# Altium schematic sheet PAGE2-MCU,LORA.SchDoc (continued)

## Library data of the parts
A1 = SR42I010-R: Antenna SMD -4dBi Gain 870MHz/928MHz Automotive 7-Pin SMD T/R; Manufacturer: Antenova; Component Kind: Standard; Manufacturer Part Number: SR42I010-R; Pricing 1: 1 = 2.36000, 10 = 1.90000, 50 = 1.90000, 100 = 1.52000, 1000 = 1.07000, 10000 = 0.95900 (USD); Stock 1: 3154; Supplier 1: Mouser; Supplier Part Number 1: 237-SR42I010-R; Library Name: Arribada-turtle-gps-tag-lora-addon.SchLib
C1 = 2.2iF/0402: Multilayer Ceramic Capacitors MLCC - SMD/SMT 0402 2.2pF 50Volts C0G +/-0.05pF; Manufacturer: Murata; Manufacturer Part Number: GJM1555C1H2R2WB01D; Voltage Rating: 50V; Capacitance: 2.2pF; Case/Package: 0402; Case Code (Imperial): 0402; Case Code (Metric): 1005; Component Kind: Standard; Depth: 0.5mm; Dielectric: C0G; Height: 0.5mm; Lead Free: Lead Free; Length: 0.039inch; Library Name: Capacitors_Schlib.SchLib; Max Operating Temperature: 125°C; Min Operating Temperature: -55°C; Mount: Surface Mount; Package Quantity: 10000; Packaging: Tape and Reel; Pricing 1: 10 = 0.19700, 100 = 0.09710, 500 = 0.05500, 2500 = 0.04500 (GBP); Radiation Hardening: No; RoHS: Yes; Stock 1: 14764; Supplier 1: Farnell; Supplier Part Number 1: 2781431; Termination: SMD/SMT; Thickness: 0.022inch; Voltage Rating (DC): 50V; Width: 0.02inch
C2 C6-C10 C13 C17 C18 C21 C23 = 100nF: MURATA - GRM188R71C104KA01J - CAP, MLCC, X7R, 0.1UF, 16V, 0603; Capacitance: 0.1; Capacitance Tolerance: ± 10%; Ceramic Capacitor Case: 0603 [1608 Metric]; Dielectric Characteristic: X7; Product Range: GRM Series; SVHC: No SVHC (20-Jun-2016; Voltage Rating: 16; Automotive Qualification Standard: -; Component Kind: Standard; ComponentLink1Description: http://www.farnell.com/datasheets/2189124.pdf; ComponentLink1URL: http://www.farnell.com/datasheets/2189124.pdf; Library Name: Capacitors.IntLib; Manufacturer: MURATA; Manufacturer Part Number: GRM188R71C104KA01J; MSL: MSL 1 - Unlimited; Operating Temperature Max: 125; Operating Temperature Min: -55; Packaging: Cut Tape; Pricing 1: 10=0.0145, 100=0.0126, 500=0.0081, 2500=0.0059, 5000=0.0052, 10000=0.0046, 50000=0.0036, 100000=0.0035 (GBP); RoHS: YES; Stock 1: 18297; Supplier 1: Farnell; Supplier Part Number 1: 2688519
C3-C5 C20 = 1uF: MURATA - GRM188R61H105KAALD - CAP, MLCC, X5R, 1UF, 50V, 0603; Capacitance: 1; Capacitance Tolerance: ± 10%; Capacitor Terminals: SMD<!--FANDB--><div class="features-and-benefits-container"><div class="features-and-benefits-description"><span class="features-benefits-value">The Murata GRM series capacitors are Multilayer Ceramic Chip Capacitors (MLCC) used for general purpose applications. These MLCC capacitors are classified into temperature compensating and high dielectric constant types depending on the change in electrostatic capacitance due to change in temperature. The temperature compensating capacitors feature a small rate of change in electrostatic capacitance as the temperature changes and are used for applications such as filters and high frequency circuit matching. The high dielectric constant capacitors use materials with a high dielectric constant and feature a large electrostatic capacitance. Typical applications are power supply decoupling and smoothing circuits.</span></div><div class="features-and-benefits-list"><ul class="features-and-benefits-list-list"><li class="features-and-benefits-list-item">Large capacity and small size in a multilayer structure</li><li class="features-and-benefits-list-item">Capacitance value ranging from 0.1pF to 220?F</li><li class="features-and-benefits-list-item">Tin plated external electrodes, excellent solderability</li><li class="features-and-benefits-list-item">Provides good high frequency characteristics</li><li class="features-and-benefits-list-item">Excellent pulse response</li><li class="features-and-benefits-list-item">High reliability</li><li class="features-and-benefits-list-item">Capacitor size varies from 0.4×0.2mm to 5.7×5.0mm</li><li class="features-and-benefits-list-item">Rated voltage of 2.5VDC to 3.15; Ceramic Capacitor Case: 0603 [1608 Metric]; Dielectric Characteristic: X5; Product Range: GRM Series; SVHC: No SVHC (17-Dec-2015); Voltage Rating: 50; Automotive Qualification Standard: -; Capacitance Tolerance ±: ± 10%; Component Kind: Standard; ComponentLink1Description: http://www.farnell.com/datasheets/2048611.pdf; ComponentLink1URL: http://www.farnell.com/datasheets/2048611.pdf; Library Name: Capacitors.IntLib; Manufacturer: MURATA; Manufacturer Part Number: GRM188R61H105KAALD; MSL: -; Operating Temperature Max: 85; Operating Temperature Min: -55; Packaging: Cut Tape; Pricing 1: 10=0.093, 100=0.062, 500=0.0507, 1000=0.0465, 2000=0.0429, 4000=0.0399, 20000=0.0372, 40000=0.0365 (GBP); RoHS: YES; Stock 1: 62682; Supplier 1: Farnell; Supplier Part Number 1: 1845736
C11 C12 C14 C15 = 20pF: MURATA - GRM1885C1H200JA01D - CAPACITOR, MLCC, C0G, 20PF, 50V, 0603; Capacitance: 20; Capacitance Tolerance: ± 5%; Ceramic Capacitor Case: 0603 [1608 Metric]; Dielectric Characteristic: C0G / NP0; Product Range: GRM Series; SVHC: No SVHC (17-Dec-2015; Voltage Rating: 50; Automotive Qualification Standard: -; Component Kind: Standard; ComponentLink1Description: http://www.farnell.com/datasheets/2048077.pdf; ComponentLink1URL: http://www.farnell.com/datasheets/2048077.pdf; Library Name: Capacitors.IntLib; Manufacturer: MURATA; Manufacturer Part Number: GRM1885C1H200JA01D; MSL: MSL 1 - Unlimited; Operating Temperature Max: 125; Operating Temperature Min: -55; Packaging: Cut Tape; Pricing 1: 10=0.0245, 100=0.0159, 500=0.0123, 1000=0.0095, 2000=0.0093, 4000=0.0073, 20000=0.0062, 40000=0.0057 (GBP); RoHS: YES; Stock 1: 5812; Supplier 1: Farnell; Supplier Part Number 1: 2456108
C16 = 330pF: Cap Ceramic 330pF 50V C0G 5% SMD 0603 125C Paper T/R; Manufacturer: Walsin Technologies; Manufacturer Part Number: 0603N331J500CT; Capacitance: 330pF; Case/Package: 0603; Case Code (Imperial): 0603; Case Code (Metric): 1608; Component Kind: Standard; Depth: 0.8mm; Dielectric: C0G; Length: 1.6mm; Library Name: Capacitors.IntLib; Max Operating Temperature: 125°C; Min Operating Temperature: -55°C; Packaging: Tape and Reel; Pricing 1: 10=0.066, 100=0.0446, 500=0.0195, 1000=0.0138 (GBP); Radiation Hardening: No; REACH SVHC: Unknown; Stock 1: 9688; Supplier 1: Farnell; Supplier Part Number 1: 2496902; Tolerance: 5%; Voltage Rating: 50V; Voltage Rating (DC): 50V
C19 = 2.2uF/0805: Multilayer Ceramic Capacitors MLCC - SMD/SMT 2.2uF 25V X7R 10%; Manufacturer: Yageo; Manufacturer Part Number: CC0805KKX7R8BB225; Capacitance: 2.2uF; Case/Package: 0805; Case Code (Imperial): 0805; Case Code (Metric): 2012; Component Kind: Standard; ComponentLink1Description: https://datasheet.ciiva.com/7009/775840-7009706.pdf; ComponentLink1URL: https://datasheet.ciiva.com/7009/775840-7009706.pdf; Composition: Ceramic; Depth: 1.25mm; Dielectric: X7R; Halogen Free: Halogen Free; Height: 0.3mm; Lead Free: Lead Free; Length: 2mm; Library Name: Capacitors.IntLib; Max Operating Temperature: 125°C; Min Operating Temperature: -55°C; Mount: Surface Mount; Number of Pins: 2; Packaging: Tape and Reel; Pricing 1: 5=0.269, 50=0.163, 250=0.109, 500=0.0929, 1500=0.0722, 3000=0.0594, 15000=0.05 (GBP); Radiation Hardening: No; REACH SVHC: Unknown; Stock 1: 0; Supplier 1: Farnell; Supplier Part Number 1: 1458904; Termination: SMD/SMT; Thickness: 0.057inch; Tolerance: 10%; Voltage Rating: 25V; Voltage Rating (DC): 25V; Width: 1.25mm
C22 = 10nF: KEMET - C0603X103K5RECAUTO - CAP, MLCC, AEC-Q200, X7R, 0.01UF, 50V; Manufacturer: TDK EPCOS; Component Kind: Standard; ComponentLink1Description: http://www.farnell.com/datasheets/2290180.pdf; ComponentLink1URL: http://www.farnell.com/datasheets/2290180.pdf; Library Name: Capacitors.IntLib; Manufacturer Part Number: C0603X103K5RECAUTO; Pricing 1: 10=0.133, 100=0.0731, 500=0.055, 1000=0.0465, 2000=0.0408, 4000=0.0336, 20000=0.0295 (GBP); Stock 1: 307350; Supplier 1: Farnell; Supplier Part Number 1: 2760492
CON1 = 53261-1471: 1.25mm (.049") Pitch PicoBlade Header, Surface Mount, Right Angle, Lead-free, 14 Circuits; Manufacturer: Molex; Body Plating: Tin; Contact Resistance: 20mR; Current Rating: 1A; Gender: Male; Glow Wire Compliant: Non-compliant; Insulation Resistance: 100MR; Number of Circuits: 14; Voltage Rating: 125V; Color: Beige; Component Kind: Standard; ComponentLink1Description: http://www.onlinecomponents.com/productFiles/mf-MOL/318250532611471_pcb_headers.pdf?src-supplier=Onlinecomponents.com; ComponentLink1URL: http://www.onlinecomponents.com/productFiles/mf-MOL/318250532611471_pcb_headers.pdf?src-supplier=Onlinecomponents.com; ComponentLink2Description: http://uk.rs-online.com/webdocs/13c4/0900766b813c44d0.pdf?src-supplier=RSComponents; ComponentLink2URL: http://uk.rs-online.com/webdocs/13c4/0900766b813c44d0.pdf?src-supplier=RSComponents; ComponentLink3Description: https://www.distrelec.biz/Web/Downloads/74/_e/gh116861-116874_e.pdf?mime=application%2Fpdf&src-supplier=Distrelec; ComponentLink3URL: https://www.distrelec.biz/Web/Downloads/74/_e/gh116861-116874_e.pdf?mime=application%2Fpdf&src-supplier=Distrelec; ComponentLink4Description: http://www.farnell.com/datasheets/1767586.pdf?src-supplier=Element14; ComponentLink4URL: http://www.farnell.com/datasheets/1767586.pdf?src-supplier=Element14; ComponentLink5Description: http://www.mouser.com/ds/2/276/0532611471_PCB_HEADERS-256969.pdf?src-supplier=Mouser; ComponentLink5URL: http://www.mouser.com/ds/2/276/0532611471_PCB_HEADERS-256969.pdf?src-supplier=Mouser; ComponentLink6Description: http://datasheet.octopart.com/532611471-Molex-datasheet-8343080.pdf?src-supplier=TME; ComponentLink6URL: http://datasheet.octopart.com/532611471-Molex-datasheet-8343080.pdf?src-supplier=TME; ComponentLink7Description: http://datasheet.octopart.com/53261-1471-Molex-datasheet-13786036.pdf?src-supplier=Molex; ComponentLink7URL: http://datasheet.octopart.com/53261-1471-Molex-datasheet-13786036.pdf?src-supplier=Molex; ComponentLink8Description: http://sigma.octopart.com/16413766/technical_drawing/Molex-53261-1471.pdf?src-supplier=Molex; ComponentLink8URL: http://sigma.octopart.com/16413766/technical_drawing/Molex-53261-1471.pdf?src-supplier=Molex; ComponentLink9Description: http://datasheet.octopart.com/53261-1471-Molex-datasheet-120153911.pdf?src-supplier=Allied+Electronics; ComponentLink9URL: http://datasheet.octopart.com/53261-1471-Molex-datasheet-120153911.pdf?src-supplier=Allied+Electronics; ComponentLink10Description: http://datasheet.octopart.com/0532611471-Molex-datasheet-11835648.pdf?src-supplier=Newark; ComponentLink10URL: http://datasheet.octopart.com/0532611471-Molex-datasheet-11835648.pdf?src-supplier=Newark; ComponentLink11Description: http://datasheet.octopart.com/53261-1471-Molex-datasheet-82508140.pdf?src-supplier=Farnell; ComponentLink11URL: http://datasheet.octopart.com/53261-1471-Molex-datasheet-82508140.pdf?src-supplier=Farnell; ComponentLink12Description: http://datasheet.octopart.com/53261-1471-Molex-datasheet-130544.pdf?src-supplier=Digi-Key; ComponentLink12URL: http://datasheet.octopart.com/53261-1471-Molex-datasheet-130544.pdf?src-supplier=Digi-Key; ComponentLink13Description: http://datasheet.octopart.com/532611471-Molex-datasheet-509611.pdf?src-supplier=Farnell; ComponentLink13URL: http://datasheet.octopart.com/532611471-Molex-datasheet-509611.pdf?src-supplier=Farnell; ComponentLink14Description: http://datasheet.octopart.com/53261-1471-Molex-datasheet-11023919.pdf?src-supplier=Farnell; ComponentLink14URL: http://datasheet.octopart.com/53261-1471-Molex-datasheet-11023919.pdf?src-supplier=Farnell; ComponentLink15Description: http://datasheet.octopart.com/53261-1471-Molex-datasheet-100429.pdf?src-supplier=Element14; ComponentLink15URL: http://datasheet.octopart.com/53261-1471-Molex-datasheet-100429.pdf?src-supplier=Element14; ComponentLink16Description: http://datasheet.octopart.com/53261-1471-Molex-datasheet-8522546.pdf?src-supplier=Element14; ComponentLink16URL: http://datasheet.octopart.com/53261-1471-Molex-datasheet-8522546.pdf?src-supplier=Element14; ComponentLink17Description: http://datasheet.octopart.com/53261-1471-Molex-datasheet-23710581.pdf?src-supplier=Electro+Sonic; ComponentLink17URL: http://datasheet.octopart.com/53261-1471-Molex-datasheet-23710581.pdf?src-supplier=Electro+Sonic; ComponentLink18Description: http://datasheet.octopart.com/53261-1471-Molex-datasheet-34442330.pdf?src-supplier=Allied+Electronics; ComponentLink18URL: http://datasheet.octopart.com/53261-1471-Molex-datasheet-34442330.pdf?src-supplier=Allied+Electronics; ComponentLink19Description: http://datasheet.octopart.com/53261-1471-Molex-datasheet-48284398.pdf?src-supplier=Newark; ComponentLink19URL: http://datasheet.octopart.com/53261-1471-Molex-datasheet-48284398.pdf?src-supplier=Newark; Contact Material: Brass,Bronze,Tin; Contact Plating: Tin; Height: 3.4mm; Housing Material: Thermoplastic; Lead Free: Lead Free; Lead Pitch: 1.25mm; Manufacturer Part Number: 53261-1471; Max Operating Temperature: 85°C; Min Operating Temperature: -40°C; Mount: Surface Mount; Number of Contacts: 14; Number of Positions: 14; Number of Rows: 1; Orientation: Right Angle; Package Quantity: 1000; Packaging: Tape and Reel; Pitch: 1.25mm; Pricing 1: 10 = 1.74000, 50 = 1.63000, 100 = 1.51000, 250 = 1.37000, 500 = 1.09000, 1500 = 0.96100 (GBP); Radiation Hardening: No; REACH SVHC: Unknown; Stock 1: 2619; Supplier 1: Farnell; Supplier Part Number 1: 1125364; Termination: SMD/SMT; Voltage Rating (AC): 125V; Voltage Rating (DC): 125V; Working Voltage: 125V; Library Name: Arribada-turtle-gps-tag-lora-addon.SchLib
CON2 = Micro USB CHINA 1: Component Kind: Standard; Library Name: USB_Connector_Lib_20150530.IntLib
CON3 = tag connecot 6 pin: Library Name: Tag_connector.IntLib
D1 D2 = LED RED: BROADCOM LIMITED - HSMS-C190 - LED, RED, 10MCD, 626NM; Forward Current If: 20; Forward Voltage: 2.1; LED Mounting: SMD; Lens Shape: Rectangular; Luminous Intensity: 10; SVHC: No SVHC (17-Dec-2015)<!--FANDB--><div class="features-and-benefits-container"><div class="features-and-benefits-description"><span class="features-benefits-value">The HSMS-C190 is a HER SMD Chip LED with untinted/diffused lens, made of GaP. It is designed in an industry standard package 1.6 x 0.8mm footprint with 0.8mm profile. It is compatible with IR reflow solder processes. The small size and wide viewing angle make this LED prime choices for backlighting applications and front panel illumination especially where space is a premium.</span></div><div class="features-and-benefits-list"><ul class="features-and-benefits-list-list"><li class="features-and-benefits-list-item">Small size</li><li class="features-and-benefits-list-item">Industry standard footprint</li><li class="features-and-benefits-list-item">Compatible with IR solder</li><li class="features-and-benefits-list-item">Diffused optics</li><li class="features-and-benefits-list-item">-40 to 85; Viewing Angle: 170°; Wavelength Typ: 626; Automotive Qualification Standard: -; Bulb Size: -; Component Kind: Standard; ComponentLink1Description: http://www.farnell.com/datasheets/313031.pdf; ComponentLink1URL: http://www.farnell.com/datasheets/313031.pdf; ComponentLink2Description: http://www.farnell.com/datasheets/1681524.pdf; ComponentLink2URL: http://www.farnell.com/datasheets/1681524.pdf; ComponentLink3Description: http://www.farnell.com/datasheets/2165483.pdf; ComponentLink3URL: http://www.farnell.com/datasheets/2165483.pdf; LED Colour: Red; Library Name: Diodes.IntLib; Manufacturer: BROADCOM LIMITED; Manufacturer Part Number: HSMS-C190; MSL: -; Packaging: Cut Tape; Pricing 1: 1=0.361, 10=0.256, 50=0.235, 100=0.106, 200=0.101 (GBP); Product Range: -; RoHS: YES; Stock 1: 7534; Supplier 1: Farnell; Supplier Part Number 1: 2497356
FB1 FB2 = BLM21PG221SN1D: FERRITE CHIP 220 OHM 0805; Manufacturer: Murata; Current: 2A; DC Resistance (DCR): 45mR; Frequency: 100MHz; Impedance: 220R; Material: Ferrite,Steel; Number of Circuits: 1; Shielding: Unshielded; Tolerance: 25%; Case/Package: 0805; Case Code (Imperial): 0805; Case Code (Metric): 2012; Component Kind: Standard; ComponentLink1Description: https://www.murata.com/en-us/products/productdata/8796738977822/ENFA0005.pdf; ComponentLink1URL: https://www.murata.com/en-us/products/productdata/8796738977822/ENFA0005.pdf; ComponentLink2Description: http://uk.rs-online.com/webdocs/1388/0900766b813885e8.pdf; ComponentLink2URL: http://uk.rs-online.com/webdocs/1388/0900766b813885e8.pdf; ComponentLink3Description: https://www.distrelec.biz/Web/Downloads/ta/_e/anBLM21P_Data_E.pdf?mime=application%2Fpdf; ComponentLink3URL: https://www.distrelec.biz/Web/Downloads/ta/_e/anBLM21P_Data_E.pdf?mime=application%2Fpdf; ComponentLink4Description: http://search.murata.co.jp/Ceramy/image/img/PDF/ENG/L0110S0100BLM21P.pdf; ComponentLink4URL: http://search.murata.co.jp/Ceramy/image/img/PDF/ENG/L0110S0100BLM21P.pdf; ComponentLink5Description: http://www.farnell.com/datasheets/1756152.pdf; ComponentLink5URL: http://www.farnell.com/datasheets/1756152.pdf; ComponentLink6Description: http://www.jameco.com/Jameco/Products/ProdDS/1845321.pdf; ComponentLink6URL: http://www.jameco.com/Jameco/Products/ProdDS/1845321.pdf; ComponentLink7Description: http://www.produktinfo.conrad.com/datenblaetter/425000-449999/442529-da-01-en-CHIP_DROSSEL_0805_220R_2000MA_2_0X1_25MM.pdf; ComponentLink7URL: http://www.produktinfo.conrad.com/datenblaetter/425000-449999/442529-da-01-en-CHIP_DROSSEL_0805_220R_2000MA_2_0X1_25MM.pdf; Contact Plating: Matte,Tin; Core Material: Ferrite; Current Rating: 2A; DC Current: 2A; Depth: 1.25mm; Height: 0.85mm; Lead Free: Lead Free; Length: 2mm; Library Name: Inductors.IntLib; Manufacturer Part Number: BLM21PG221SN1D; Max Current Rating: 2A; Max DC Current: 2A; Max Operating Temperature: 125°C; Military Standard: Not; Min Operating Temperature: -55°C; Number of Lines: 1; Number of Pins: 2; Package Quantity: 1; Packaging: Tape and Reel; Pricing 1: 10=0.0521, 100=0.0337, 500=0.0251, 1000=0.0207, 2000=0.0196, 4000=0.0156, 20000=0.0145 (GBP); Radiation Hardening: No; REACH SVHC: No SVHC; Stock 1: 8102; Supplier 1: Farnell; Supplier Part Number 1: 1515661; Termination: SMD/SMT; Test Frequency: 100MHz; Width: 1.25mm
H1-H4 = Hole: Library Name: gps-tag-lora-addon.SchLib
L1 L2 = 33nH/0402: FIXED IND 33NH 200MA 580 MOHM; Manufacturer: Murata; Manufacturer Part Number: LQG15HS33NJ02D; Core Material: Air; DC Resistance (DCR): 580mR; Q Factor: 8; Self Resonant Frequency: 1.6GHz; Shielding: Unshielded; Test Frequency: 100MHz; Tolerance: 5%; Case/Package: 0402; Case Code (Imperial): 0402; Case Code (Metric): 1005; Component Kind: Standard; ComponentLink1Description: https://datasheet.ciiva.com/11799/0900766b8090d685-11799024.pdf; ComponentLink1URL: https://datasheet.ciiva.com/11799/0900766b8090d685-11799024.pdf; ComponentLink2Description: https://datasheet.ciiva.com/1038/l0075s0083lqg15hs-1038140.pdf; ComponentLink2URL: https://datasheet.ciiva.com/1038/l0075s0083lqg15hs-1038140.pdf; ComponentLink3Description: https://datasheet.ciiva.com/1038/l0075s0083lqg15hs-1038141.pdf; ComponentLink3URL: https://datasheet.ciiva.com/1038/l0075s0083lqg15hs-1038141.pdf; ComponentLink4Description: https://datasheet.ciiva.com/16207/l0075s0083lqg15hs-16207871.pdf; ComponentLink4URL: https://datasheet.ciiva.com/16207/l0075s0083lqg15hs-16207871.pdf; ComponentLink5Description: https://datasheet.ciiva.com/1038/l0075s0083lqg15hs-1038139.pdf; ComponentLink5URL: https://datasheet.ciiva.com/1038/l0075s0083lqg15hs-1038139.pdf; ComponentLink6Description: https://datasheet.ciiva.com/17279/l0075s0083lqg15hs-17279253.pdf; ComponentLink6URL: https://datasheet.ciiva.com/17279/l0075s0083lqg15hs-17279253.pdf; ComponentLink7Description: https://datasheet.ciiva.com/4977/o05e-4977560.pdf; ComponentLink7URL: https://datasheet.ciiva.com/4977/o05e-4977560.pdf; ComponentLink8Description: https://datasheet.ciiva.com/8510/l0075s0083lqg15hs-8510857.pdf; ComponentLink8URL: https://datasheet.ciiva.com/8510/l0075s0083lqg15hs-8510857.pdf; Current Rating: 200mA; DC Current: 200mA; Depth: 0.55mm; Height: 0.5mm; Height - Seated (Max): 0.022inch; Inductance: 33nh; Lead Free: Lead Free; Length: 1mm; Library Name: Inductors.IntLib; Max DC Current: 200mA; Max Operating Temperature: 125°C; Military Standard: Not; Min Operating Temperature: -55°C; Mount: Surface Mount; Package Quantity: 10000; Packaging: Tape and Reel; Pricing 1: 1=0.1, 10=0.061, 100=0.0404, 500=0.0337, 1000=0.0283, 2500=0.0242 (USD); Radiation Hardening: No; Stock 1: 168013; Supplier 1: Digi-Key; Supplier Part Number 1: 490-2629-1-ND; Termination: SMD/SMT; Width: 0.5mm
L3 = 4.3nH/0402: Inductor RF Chip Multi-Layer 4.3nH 0.3nH 100MHz 8Q-Factor Air 300mA 210mOhm DCR 0402 Paper T/R; Manufacturer: Murata; Manufacturer Part Number: LQG15HN4N3S02D; DC Resistance (DCR): 210mR; Q Factor: 8; Self Resonant Frequency: 6GHz; Shielding: Unshielded; Case/Package: 0402; Case Code (Imperial): 0402; Case Code (Metric): 1005; Component Kind: Standard; ComponentLink1Description: http://uk.rs-online.com/webdocs/0f10/0900766b80f10808.pdf?src-supplier=RSComponents; ComponentLink1URL: http://uk.rs-online.com/webdocs/0f10/0900766b80f10808.pdf?src-supplier=RSComponents; ComponentLink2Description: http://search.murata.co.jp/Ceramy/image/img/PDF/ENG/L0075S0083LQG15HN.pdf?src-supplier=Avnet; ComponentLink2URL: http://search.murata.co.jp/Ceramy/image/img/PDF/ENG/L0075S0083LQG15HN.pdf?src-supplier=Avnet; ComponentLink3Description: http://www.farnell.com/datasheets/66026.pdf?src-supplier=Element14; ComponentLink3URL: http://www.farnell.com/datasheets/66026.pdf?src-supplier=Element14; ComponentLink4Description: https://www.verical.com/server-webapp/html/getDatasheet?partID=31252&src-supplier=Verical; ComponentLink4URL: https://www.verical.com/server-webapp/html/getDatasheet?partID=31252&src-supplier=Verical; ComponentLink5Description: http://search.murata.co.jp/Ceramy/image/img/PDF/ENG/L0075S0083LQG15HN.pdf?src-supplier=Avnet+Europe; ComponentLink5URL: http://search.murata.co.jp/Ceramy/image/img/PDF/ENG/L0075S0083LQG15HN.pdf?src-supplier=Avnet+Europe; ComponentLink6Description: https://search.murata.co.jp/Ceramy/image/img/P02/partnumberingAT_LQ3E.pdf?src-supplier=Digi-Key; ComponentLink6URL: https://search.murata.co.jp/Ceramy/image/img/P02/partnumberingAT_LQ3E.pdf?src-supplier=Digi-Key; Core Material: Air; Current: 300mA; Current Rating: 300mA; DC Current: 300mA; Depth: 0.55mm; Height: 0.5mm; Height - Seated (Max): 0.022inch; Inductance: 4.3nh; Lead Free: Lead Free; Length: 1.05mm; Library Name: Inductors_Schlib.SchLib; Max DC Current: 300mA; Max Operating Temperature: 125°C; Military Standard: Not; Min Operating Temperature: -55°C; Mount: Surface Mount; Package Quantity: 10000; Packaging: Tape and Reel; Pricing 1: 1 = 0.10000, 10 = 0.06100, 100 = 0.04040, 500 = 0.03366, 1000 = 0.02827, 2500 = 0.02423 (USD); Radiation Hardening: No; REACH SVHC: No SVHC; RoHS: Yes; Stock 1: 3502; Supplier 1: Digi-Key; Supplier Part Number 1: 490-6563-1-ND; Termination: SMD/SMT; Test Frequency: 100MHz; Width: 0.02inch
P1 P2 = Header 4: Header, 4-Pin; Component Kind: Standard; LatestRevisionDate: 17-Jul-2002; LatestRevisionNote: Re-released for DXP Platform.; Library Name: Miscellaneous Connectors.IntLib; Signal Integrity: Connector; Publisher: Altium Limited
P3 = Header 6: Header, 6-Pin; Component Kind: Standard; LatestRevisionDate: 17-Jul-2002; LatestRevisionNote: Re-released for DXP Platform.; Library Name: Miscellaneous Connectors.IntLib; Signal Integrity: Connector; Publisher: Altium Limited
P4 = Header 2: Header, 2-Pin; LatestRevisionDate: 17-Jul-2002; LatestRevisionNote: Re-released for DXP Platform.; Library Name: Miscellaneous Connectors.IntLib; Signal Integrity: Connector; Publisher: Altium Limited
R1-R3 R10 R12-R18 R20 R21 = 0R: BOURNS - CR0603-J/-000ELF - RES, THICK FILM, 0R, 5%, 0.1W, 0603; Power Rating: 100; Product Range: CR Series; Resistance: 0; Resistor Case Style: 0603 [1608 Metric]; Resistor Mounting: Surface Mount Device<!--FANDB--><div class="features-and-benefits-container"><div class="features-and-benefits-description"><span class="features-benefits-value">The Bourns CR series are standard thick film chip resistors. These resistors provide flexibility of performance, space saving and low cost. The Resistance range includes E96 and E24 decade values from 1ohm to 10Mohm.</span></div><div class="features-and-benefits-list"><ul class="features-and-benefits-list-list"><li class="features-and-benefits-list-item">0201, 0402, 0603, 0805, 1206, 1210, 2010, 2512 standard case sizes available</li><li class="features-and-benefits-list-item">±1% and ±5% tolerance</li><li class="features-and-benefits-list-item">±100ppm/°C, ±200ppm/°C and ±250ppm/°C TCR values available</li><li class="features-and-benefits-list-item">Power rating selectable for 50mW, 63mW, 0.1W, 0.125W, 0.25W, 0.5W and 1W based on ordering</li><li class="features-and-benefits-list-item">Jumpers are available for all size</li><li class="features-and-benefits-list-item">3 layer termination process with nickel barrier prevents leaching & provides excellent solderability</li><li class="features-and-benefits-list-item">Suitable for all type of soldering processes</li><li class="features-and-benefits-list-item">Tight dimensional tolerances</li><li class="features-and-benefits-list-item">Maximum working voltage depends on case size</li><li class="features-and-benefits-list-item">Operating temperature of -55°C to +125°C(CR0201) and -55°C to +155; SVHC: No SVHC (17-Dec-2015); Voltage Rating: 50; Automotive Qualification Standard: -; Component Kind: Standard; ComponentLink1Description: http://www.bourns.com/engineering/CHPREZTR/cr0603.stp; ComponentLink1URL: http://www.bourns.com/engineering/CHPREZTR/cr0603.stp; ComponentLink2Description: http://www.farnell.com/datasheets/2178762.pdf; ComponentLink2URL: http://www.farnell.com/datasheets/2178762.pdf; Library Name: Resistors.IntLib; Manufacturer: BOURNS; Manufacturer Part Number: CR0603-J/-000ELF; MSL: MSL 1 - Unlimited; Packaging: Cut Tape; Pricing 1: 10=0.0146, 250=0.0097, 1000=0.0073, 5000=0.0049, 12500=0.0042 (GBP); Resistance Tolerance: ± 5%; Resistor Element Material: Thick Film; RoHS: YES; Stock 1: 58200; Supplier 1: Farnell; Supplier Part Number 1: 2008343; Temperature Coefficient: -
R4 R5 = 470R: MULTICOMP - MCMR06X471 JTL - RES, CERAMIC, 470R, 5%, 0.1W, 0603; Component Kind: Standard; ComponentLink1Description: http://www.farnell.com/datasheets/1716707.pdf; ComponentLink1URL: http://www.farnell.com/datasheets/1716707.pdf; Library Name: Resistors.IntLib; Manufacturer: MULTICOMP; Manufacturer Part Number: MCMR06X471 JTL; Pricing 1: 10=0.0089, 100=0.0076, 500=0.0063, 1000=0.0049, 2500=0.0033, 5000=0.0024, 25000=0.0016, 50000=0.0013 (GBP); RoHS: Y-EX; Stock 1: 3786; Supplier 1: Farnell; Supplier Part Number 1: 2073513
R6 R7 = 4k7: MULTICOMP - MCMR06X4701FTL - RES, CERAMIC, 4K7, 1%, 0.1W, 0603; Power Rating: 100; Product Range: MCMR Series; Resistance: 4.7; Resistor Case Style: 0603 [1608 Metric]; SVHC: No SVHC (12-Jan-2017)<!--FANDB--><div class="features-and-benefits-container"><div class="features-and-benefits-description"><span class="features-benefits-value">The Multicomp MCMR series resistors are automotive grade, anti sulphured thick film chip resistor. The resistance range includes E24 and E96 decade values from 1ohm to 10Mohm. These resistors are constructed on top of a high grade ceramic body.</span></div><div class="features-and-benefits-list"><ul class="features-and-benefits-list-list"><li class="features-and-benefits-list-item">EIA 0402, 0603, 0805, 1206 chip sizes available</li><li class="features-and-benefits-list-item">High reliability and stability</li><li class="features-and-benefits-list-item">Automotive grade AEC-Q200 compliant</li><li class="features-and-benefits-list-item">Complaint with (IEC 60068-1) 55/155/56 climatic category</li><li class="features-and-benefits-list-item">Maximum operation voltage of 50V, 75V, 150V and 200V</li><li class="features-and-benefits-list-item">Power rating of 62.5mW, 100mW, 125mW and 250mW</li><li class="features-and-benefits-list-item">Available in ±1% and ±5% tolerance</li><li class="features-and-benefits-list-item">Temperature coefficient (TCR) of +200ppm/°C, +100ppm/°C and -200ppm/°C to +400ppm/°C</li><li class="features-and-benefits-list-item">Operating temperature range -55°C to 155; Temperature Coefficient: ± 100; Voltage Rating: 75; Automotive Qualification Standard: -; Component Kind: Standard; ComponentLink1Description: http://www.farnell.com/datasheets/1716707.pdf; ComponentLink1URL: http://www.farnell.com/datasheets/1716707.pdf; Library Name: Resistors.IntLib; Manufacturer: MULTICOMP; Manufacturer Part Number: MCMR06X4701FTL; Packaging: Cut Tape; Pricing 1: 10=0.0085, 100=0.0051, 500=0.0036, 1000=0.0028, 2500=0.0023 (GBP); Resistance Tolerance: ± 1%; Resistor Element Material: Ceramic; RoHS: Y-EX; Stock 1: 218618; Supplier 1: Farnell; Supplier Part Number 1: 2073509
R8 R11 R22 = 10k: MULTICOMP - MCMR06X103 JTL - RES, CERAMIC, 10K, 5%, 0.1W, 0603; Automotive Qualification Standard: -<!--FANDB--><div class="features-and-benefits-container"><div class="features-and-benefits-description"><span class="features-benefits-value">The Multicomp MCMR series resistors are automotive grade, anti sulphured thick film chip resistor. The resistance range includes E24 and E96 decade values from 1ohm to 10Mohm. These resistors are constructed on top of a high grade ceramic body.</span></div><div class="features-and-benefits-list"><ul class="features-and-benefits-list-list"><li class="features-and-benefits-list-item">EIA 0402, 0603, 0805, 1206 chip sizes available</li><li class="features-and-benefits-list-item">High reliability and stability</li><li class="features-and-benefits-list-item">Automotive grade AEC-Q200 compliant</li><li class="features-and-benefits-list-item">Complaint with (IEC 60068-1) 55/155/56 climatic category</li><li class="features-and-benefits-list-item">Maximum operation voltage of 50V, 75V, 150V and 200V</li><li class="features-and-benefits-list-item">Power rating of 62.5mW, 100mW, 125mW and 250mW</li><li class="features-and-benefits-list-item">Available in ±1% and ±5% tolerance</li><li class="features-and-benefits-list-item">Temperature coefficient (TCR) of +200ppm/°C, +100ppm/°C and -200ppm/°C to +400ppm/°C</li><li class="features-and-benefits-list-item">Operating temperature range -55°C to 155; Power Rating: 100; Product Range: MCMR Series; Resistance: 10; Resistor Case Style: 0603 [1608 Metric]; Temperature Coefficient: ± 100; Voltage Rating: 75; Component Kind: Standard; ComponentLink1Description: http://www.farnell.com/datasheets/1716707.pdf; ComponentLink1URL: http://www.farnell.com/datasheets/1716707.pdf; Library Name: Resistors.IntLib; Manufacturer: MULTICOMP; Manufacturer Part Number: MCMR06X103 JTL; Packaging: Cut Tape; Pricing 1: 10=0.0087, 100=0.0074, 500=0.0061, 1000=0.0048, 2500=0.0032, 5000=0.0024, 25000=0.0016, 50000=0.0013 (GBP); Resistance Tolerance: ± 5%; Resistor Element Material: Ceramic; RoHS: Y-EX; Stock 1: 19791; Supplier 1: Farnell; Supplier Part Number 1: 2073356
R9 = 220R: MULTICOMP - MCWR06X2200FTL - RES, THICK FILM, 220R, 1%, 0.1W, 0603; Power Rating: 100; Product Range: MCWR Series; Resistance: 220; Resistor Case Style: 0603 [1608 Metric]; SVHC: No SVHC (07-Jul-2017)<!--FANDB--><div class="features-and-benefits-container"><div class="features-and-benefits-desc-container"><span class="features-and-benefits-container-desc">SMD Chip Resistor, Thick Film, 220 ohm, 50 V, 0603 [1608 Metric], 100 mW, ± 1; Temperature Coefficient: ± 100; Voltage Rating: 50; Automotive Qualification Standard: -; Component Kind: Standard; ComponentLink1Description: http://www.farnell.com/datasheets/1849611.pdf; ComponentLink1URL: http://www.farnell.com/datasheets/1849611.pdf; Library Name: Resistors.IntLib; Manufacturer: MULTICOMP; Manufacturer Part Number: MCWR06X2200FTL; Packaging: Cut Tape; Pricing 1: 10=0.0031, 100=0.0018, 500=0.0013, 1000=0.001, 2500=0.0008 (GBP); Resistance Tolerance: ± 1%; Resistor Element Material: Thick Film; RoHS: Y-EX; Stock 1: 124834; Supplier 1: Farnell; Supplier Part Number 1: 2447298
R19 = 1M: MULTICOMP - MC0063W060311M - RES, THICK FILM, 1M, 1%, 0.063W, 0603; External Depth: 0.45; External Length / Height: 1.6; External Width: 0.8; No. of Pins: 2; Power Rating: 63; Product Range: MC Series; Reel Quantity: 5000; Resistance: 1; Resistor Case Style: 0603 [1608 Metric]; Resistor Mounting: Surface Mount Device; SVHC: No SVHC (17-Dec-2015); Temperature Coeff. +: 100; Temperature Coefficient: ± 100; Voltage Rating: 50; Voltage Rating V DC: 50V<!--FANDB--><div class="features-and-benefits-container"><div class="features-and-benefits-description"><span class="features-benefits-value">The Multicomp MC series current sense resistors are thick film chip resistors. The resistance range includes E96 and E24 decade values from 1ohm to 10Mohm.</span></div><div class="features-and-benefits-list"><ul class="features-and-benefits-list-list"><li class="features-and-benefits-list-item">EIA 0402, 0603, 0805, 1206 chip sizes available</li><li class="features-and-benefits-list-item">Small size and low weight</li><li class="features-and-benefits-list-item">Suitable for both wave and reflow soldering</li><li class="features-and-benefits-list-item">Reduction of assembly costs</li><li class="features-and-benefits-list-item">Maximum working voltage of 50V(0402, 0603), 150V(0805), 200V(1206)</li><li class="features-and-benefits-list-item">Available in ±1%, ±2% and ±5% tolerance</li><li class="features-and-benefits-list-item">Power rating of 62.5mW, 100mW and 125mW</li><li class="features-and-benefits-list-item">±400ppm/°C(1ohm to 10ohm), ±200ppm/°C(11ohm to 100ohm), ±100; Automotive Qualification Standard: -; Component Kind: Standard; ComponentLink1Description: http://www.farnell.com/datasheets/1788326.pdf; ComponentLink1URL: http://www.farnell.com/datasheets/1788326.pdf; ComponentLink2Description: http://www.farnell.com/cad/1558928.zip; ComponentLink2URL: http://www.farnell.com/cad/1558928.zip; Library Name: Resistors.IntLib; Manufacturer: MULTICOMP; Manufacturer Part Number: MC0063W060311M; Operating Temperature Max: 155; Operating Temperature Min: -55; Operating Temperature Range: -55°C to +155; Packaging: Cut Tape; Pricing 1: 10=0.0011, 100=0.001, 500=0.0009, 1000=0.0008, 2500=0.0007 (GBP); Resistance Tolerance: ± 1%; Resistor Element Material: Thick Film; RoHS: Y-EX; Stock 1: 333415; Supplier 1: Farnell; Supplier Part Number 1: 9330410; Tape Width: 8; Temperature Coeff. -: 100; Tolerance +: 1%; Tolerance -: 1%
R23 = 0R/0402: RESISTOR, 0402, 0R, ANTI SULFURATION; Manufacturer: Multicomp; Manufacturer Part Number: MCMR04X000PTL; Power Rating: 62.5mW; Resistance: 0mR; Temperature Coefficient: 400ppm/°C; Voltage Rating: 50V; Case/Package: 0402; Case Code (Imperial): 0402; Case Code (Metric): 1005; Component Kind: Standard; ComponentLink1Description: https://datasheet.ciiva.com/7776/1716705-7776974.pdf; ComponentLink1URL: https://datasheet.ciiva.com/7776/1716705-7776974.pdf; ComponentLink2Description: https://datasheet.ciiva.com/28677/1716705-28677161.pdf; ComponentLink2URL: https://datasheet.ciiva.com/28677/1716705-28677161.pdf; Composition: Ceramic; Library Name: Resistors.IntLib; Packaging: Cut Tape; Pricing 1: 10=0.0057, 100=0.0034, 500=0.0024, 2500=0.0019, 5000=0.0015 (EUR); REACH SVHC: No SVHC; Stock 1: 0; Supplier 1: Farnell; Supplier Part Number 1: 2072513; Voltage Rating (DC): 50V
R24 R25 = 27R: MULTICOMP         MCWR06X27R0FTL            Surface Mount Chip Resistor, Thick Film, MCWR Series, 27 ohm, 100 mW,  1%, 50 V; Power Rating: 100mW; Temperature Coefficient: 100ppm/°C; Voltage Rating: 50V; Case/Package: 0603; Case Code (Imperial): 0603; Case Code (Metric): 1608; Component Kind: Standard; ComponentLink1Description: https://datasheet.ciiva.com/46436/1849611-46436376.pdf; ComponentLink1URL: https://datasheet.ciiva.com/46436/1849611-46436376.pdf; Composition: Thick Film; Library Name: Resistors.IntLib; Manufacturer: Multicomp; Manufacturer Part Number: MCWR06X27R0FTL; Mount: Surface Mount; Packaging: Cut Tape; REACH SVHC: Unknown; Resistance: 27R; Stock 1: 0; Supplier 1: Farnell; Supplier Part Number 1: 2447316; Tolerance: 1%; Voltage Rating (DC): 50V
S1 = KMR221NGLFS: SWITCH TACTILE SPST-NO 0.05A 32V; Manufacturer: ITT C&K; Actuator Length: 0.5mm; Actuator Type: Standard; Current Rating: 50mA; Illumination Type: Non-Illuminated; Mechanical Life: 200000Cycles; Operating Force: 2N; Power Rating: 1W; Switch Function: Off-Mom; Switch Travel: 0.25mm; Throw Configuration: SPST; Case/Package: SMD/SMT; Component Kind: Standard; ComponentLink1Description: https://www.ckswitches.com/media/1479/kmr2.pdf?src-supplier=Digi-Key; ComponentLink1URL: https://www.ckswitches.com/media/1479/kmr2.pdf?src-supplier=Digi-Key; ComponentLink2Description: http://www.ck-components.com/14414/kmr2_9aug12.pdf/?src-supplier=Avnet+Europe; ComponentLink2URL: http://www.ck-components.com/14414/kmr2_9aug12.pdf/?src-supplier=Avnet+Europe; ComponentLink3Description: http://www1.futureelectronics.com/doc/C%20-%20K%20COMPONENTS/KMR221NGLFS.pdf?src-supplier=Future+Electronics; ComponentLink3URL: http://www1.futureelectronics.com/doc/C%20-%20K%20COMPONENTS/KMR221NGLFS.pdf?src-supplier=Future+Electronics; Contact Material: Silver; Contact Plating: Silver; Depth: 4.6mm; Lead Free: Lead Free; Length: 2.8mm; Manufacturer Part Number: KMR221NGLFS; Max Current Rating: 50mA; Max Operating Temperature: 85°C; Max Voltage Rating (DC): 32V; Min Operating Temperature: -40°C; Mount: Surface Mount; Orientation: Straight; Package Quantity: 1; Packaging: Tape and Reel; Pricing 1: 10 = 0.29100, 500 = 0.27600, 1000 = 0.21800, 5000 = 0.18500, 10000 = 0.18100 (GBP); Radiation Hardening: No; RoHS: Yes; Stock 1: 3038; Supplier 1: Farnell; Supplier Part Number 1: 2845727; Termination: Gull Wing; Voltage Rating (DC): 32V; Library Name: Arribada-turtle-gps-tag-lora-addon.SchLib
U1 = STM32L072CZT6: IC MCU 32BIT 192KB FLASH 48LQFP; Manufacturer: STMicroelectronics; Component Kind: Standard; Library Name: STMicroelectronics STM32 L0.IntLib; Manufacturer Part Number: STM32L072CZT6; Pricing 1: 1 = 4.14000, 10 = 3.52000, 50 = 3.52000, 100 = 3.05000, 1000 = 2.19000, 10000 = 2.08000 (USD); Stock 1: 1435; Supplier 1: Mouser; Supplier Part Number 1: 511-STM32L072CZT6
U3 = 24AA02E48T-I/OT: MICROCHIP   24AA02E48T-I/OT   EEPROM, EUI-48 Node Identity, 2 Kbit, 2 BLK (128K x 8bit), 400 kHz, I2C, SOT-23, 5 Pins; Access Time: 3500ns; Density: 2Kb; Frequency: 400kHz; Interface: I2C,Serial; Max Frequency: 100kHz; Max Supply Voltage: 5.5V; Min Supply Voltage: 1.7V; Nominal Supply Current: 3mA; Operating Supply Voltage: 5V; Case/Package: SOT-23; Component Kind: Standard; ComponentLink1Description: http://www.farnell.com/datasheets/1725195.pdf?src-supplier=Element14; ComponentLink1URL: http://www.farnell.com/datasheets/1725195.pdf?src-supplier=Element14; ComponentLink2Description: http://www.farnell.com/datasheets/631538.pdf?src-supplier=Element14; ComponentLink2URL: http://www.farnell.com/datasheets/631538.pdf?src-supplier=Element14; ComponentLink3Description: http://ww1.microchip.com/downloads/en/DeviceDoc/22124D.pdf?src-supplier=Avnet+Europe; ComponentLink3URL: http://ww1.microchip.com/downloads/en/DeviceDoc/22124D.pdf?src-supplier=Avnet+Europe; ComponentLink4Description: http://www.microchip.com/mymicrochip/filehandler.aspx?ddocname=en538826&src-supplier=Future+Electronics; ComponentLink4URL: http://www.microchip.com/mymicrochip/filehandler.aspx?ddocname=en538826&src-supplier=Future+Electronics; ComponentLink5Description: http://www.produktinfo.conrad.com/datenblaetter/650000-674999/651228-da-01-en-EEPROM_24AA02E48T_I_OT_SOT_23_5_MCP.pdf?src-supplier=Conrad; ComponentLink5URL: http://www.produktinfo.conrad.com/datenblaetter/650000-674999/651228-da-01-en-EEPROM_24AA02E48T_I_OT_SOT_23_5_MCP.pdf?src-supplier=Conrad; Height: 1.3mm; Lead Free: Lead Free; Length: 3.1mm; Manufacturer: Microchip; Manufacturer Part Number: 24AA02E48T-I/OT; Max Operating Temperature: 85°C; Memory Size: 2Kb; Memory Type: EEPROM; Min Operating Temperature: -40°C; Mount: Surface Mount; Number of Pins: 5; Package Quantity: 3000; Packaging: Tape and Reel; Pricing 1: 1 = 0.17600, 10 = 0.17100, 25 = 0.16400, 50 = 0.16000, 100 = 0.15600 (GBP); Radiation Hardening: No; REACH SVHC: No SVHC; RoHS: Yes; Stock 1: 13638; Supplier 1: Farnell; Supplier Part Number 1: 1688855; Termination: SMD/SMT; Width: 1.8mm; Library Name: Arribada-turtle-gps-tag-lora-addon.SchLib
U4 = USBLC6-2SC6: TVS DIODE 5.25VWM 17VC SOT23-6; Manufacturer: STMicroelectronics; Breakdown Voltage: 6V; Capacitance: 3.5pF; Clamping Voltage: 17V; Data Rate: 480Mbps; Direction: Bidirectional,Unidirectional; Element Configuration: Dual; ESD Protection: Yes; Leakage Current: 150nA; Number of Bidirectional Channels: 2; Number of Elements: 1; Operating Supply Voltage: 1.1V; Peak Pulse Current: 5A; Reverse Standoff Voltage: 5.25V; Test Current: 1mA; Working Voltage: 5V; Case/Package: SOT-23; Component Kind: Standard; ComponentLink1Description: http://www.farnell.com/datasheets/1690354.pdf; ComponentLink1URL: http://www.farnell.com/datasheets/1690354.pdf; ComponentLink2Description: http://www.st.com/content/ccc/resource/technical/document/datasheet/06/1d/48/9c/6c/20/4a/b2/CD00050750.pdf/files/CD00050750.pdf/jcr:content/translations/en.CD00050750.pdf; ComponentLink2URL: http://www.st.com/content/ccc/resource/technical/document/datasheet/06/1d/48/9c/6c/20/4a/b2/CD00050750.pdf/files/CD00050750.pdf/jcr:content/translations/en.CD00050750.pdf; ComponentLink3Description: http://www.st.com/web/en/resource/technical/document/datasheet/CD00050750.pdf; ComponentLink3URL: http://www.st.com/web/en/resource/technical/document/datasheet/CD00050750.pdf; ComponentLink4Description: http://datasheet.iiic.cc/stmicroelectronics/USBLC6-2SC6.pdf; ComponentLink4URL: http://datasheet.iiic.cc/stmicroelectronics/USBLC6-2SC6.pdf; ComponentLink5Description: http://www.produktinfo.conrad.com/datenblaetter/1100000-1199999/001184109-da-01-en-IC_ESD_PROTECTI_USBLC6_2SC6_SOT_23_6_STM.pdf; ComponentLink5URL: http://www.produktinfo.conrad.com/datenblaetter/1100000-1199999/001184109-da-01-en-IC_ESD_PROTECTI_USBLC6_2SC6_SOT_23_6_STM.pdf; Contact Plating: Matte,Tin; Depth: 1.75mm; Height: 1.3mm; Lead Free: Lead Free; Length: 3.05mm; Manufacturer Part Number: USBLC6-2SC6; Max Breakdown Voltage: 6V; Max Operating Temperature: 125°C; Max Reverse Leakage Current: 150nA; Min Breakdown Voltage: 6V; Min Operating Temperature: -40°C; Mount: Surface Mount; Number of Channels: 2; Number of Pins: 6; Package Quantity: 3000; Packaging: Tape and Reel; Polarity: Bidirectional,Unidirectional; Power Line Protection: Yes; Pricing 1: 5=0.327, 50=0.216, 100=0.165, 500=0.155, 1500=0.145 (GBP); Radiation Hardening: No; REACH SVHC: No SVHC; Reverse Breakdown Voltage: 6V; Stock 1: 40838; Supplier 1: Farnell; Supplier Part Number 1: 1269406; Termination: SMD/SMT; Width: 1.75mm; Library Name: Arribada-turtle-gps-tag-lora-addon.SchLib
U5 = TPS78326DDCT: IC REG LINEAR 2.6V 150MA SOT23-5; Manufacturer: Texas Instruments; Accuracy: 2%; Dropout Voltage: 130mV; Max Input Voltage: 5.5V; Max Power Dissipation: 500mW; Min Input Voltage: 2.2V; Number of Outputs: 1; Output Type: Fixed; Polarity: Positive; Quiescent Current: 500nA; Case/Package: TSOT; Component Kind: Standard; ComponentLink1Description: http://www.ti.com/lit/ds/symlink/tps783.pdf?src-supplier=Digi-Key; ComponentLink1URL: http://www.ti.com/lit/ds/symlink/tps783.pdf?src-supplier=Digi-Key; ComponentLink2Description: http://www.mouser.com/ds/2/405/sbvs133a-521627.pdf?src-supplier=Mouser; ComponentLink2URL: http://www.mouser.com/ds/2/405/sbvs133a-521627.pdf?src-supplier=Mouser; ComponentLink3Description: http://www.farnell.com/datasheets/1865508.pdf?src-supplier=Element14; ComponentLink3URL: http://www.farnell.com/datasheets/1865508.pdf?src-supplier=Element14; Height: 1mm; Lead Free: Lead Free; Length: 3.05mm; Manufacturer Part Number: TPS78326DDCT; Max Operating Temperature: 105°C; Max Output Current: 150mA; Min Current Limit: 150mA; Min Operating Temperature: -40°C; Mount: Surface Mount; Nominal Output Voltage: 2.6V; Number of Pins: 5; Number of Regulators: 1; Output Current: 150mA; Output Voltage: 2.6V; Output Voltage Accuracy: 2%; Package Quantity: 1; Packaging: Tape and Reel; Power Dissipation: 500mW; Power Rating: 500mW; Pricing 1: 1 = 0.96000, 10 = 0.85700, 100 = 0.66810 (USD); Radiation Hardening: No; REACH SVHC: No SVHC; RoHS: Yes; Stock 1: 873; Supplier 1: Digi-Key; Supplier Part Number 1: 296-35542-1-ND; Width: 1.75mm; Library Name: gps-tag-lora-addon.SchLib
U6 = MPU-9250: IMU ACCEL/GYRO/MAG I2C/SPI 24QFN; Manufacturer: TDK InvenSense; Interface: I2C; Max Supply Voltage: 3.6V; Min Supply Voltage: 2.4V; Output Type: SPI; Sensor Type: Accelerometer; Case/Package: QFN; Component Kind: Standard; ComponentLink1Description: https://datasheet.ciiva.com/29175/mpu-9250-29175105.pdf?src-supplier=Digi-Key; ComponentLink1URL: https://datasheet.ciiva.com/29175/mpu-9250-29175105.pdf?src-supplier=Digi-Key; Height: 1mm; Length: 3.1mm; Library Name: 9DoF-Accelerometer.SchLib; Manufacturer Part Number: MPU-9250; Max Operating Temperature: 85°C; Min Operating Temperature: -40°C; Mount: Surface Mount; Number of Pins: 24; Package Quantity: 1; RoHS: Yes; Stock 1: 0; Supplier 1: RSComponents; Supplier Part Number 1: 883-7942P; Width: 3.1mm
X1 = ABS06-107-32.768KHZ-T: 32.768KHz 4pF Max. ESR 81 Kohms; Manufacturer: Abracon; ESR (Equivalent Series Resistance): 60kR; Frequency Stability: 175ppm; Frequency Tolerance: 20ppm; Series Resistance: 80kR; Case/Package: 0805; Case Code (Imperial): 0805; Case Code (Metric): 2012; Component Kind: Standard; ComponentLink1Description: https://datasheet.ciiva.com/23910/1759010-23910051.pdf; ComponentLink1URL: https://datasheet.ciiva.com/23910/1759010-23910051.pdf; ComponentLink2Description: https://datasheet.ciiva.com/24028/abs06-107-32-768khz-t-24028377.pdf; ComponentLink2URL: https://datasheet.ciiva.com/24028/abs06-107-32-768khz-t-24028377.pdf; ComponentLink3Description: https://datasheet.ciiva.com/29630/abs06-107-32-768khz-t-29630938.pdf; ComponentLink3URL: https://datasheet.ciiva.com/29630/abs06-107-32-768khz-t-29630938.pdf; ComponentLink4Description: https://datasheet.ciiva.com/29630/abs06-107-32-768khz-t-29630939.pdf; ComponentLink4URL: https://datasheet.ciiva.com/29630/abs06-107-32-768khz-t-29630939.pdf; Frequency: 32.768kHz; Height: 0.024inch; Lead Free: Lead Free; Length: 0.079inch; Library Name: Crystals.IntLib; Load Capacitance: 4pF; Manufacturer Part Number: ABS06-107-32.768KHZ-T; Max Operating Temperature: 85°C; Min Operating Temperature: -40°C; Mount: Surface Mount; Number of Pins: 2; Package Quantity: 3000; Packaging: Tape and Reel; Pricing 1: 5=0.733, 50=0.59, 250=0.583, 500=0.582, 1500=0.581, 3000=0.58, 15000=0.579 (EUR); Radiation Hardening: No; REACH SVHC: No SVHC; Stock 1: 7053; Supplier 1: Farnell; Supplier Part Number 1: 2467860; Termination: SMD/SMT; Tolerance: 20ppm; Width: 0.047inch
Y1 = 16MHz: 16MHz ±20ppm Crystal 10pF 150 Ohm -40°C ~ 85°C  Surface Mount 4-SMD, No Lead (DFN, LCC); Manufacturer: Abracon; Manufacturer Part Number: ABM10-16.000MHZ-D30-T3; ESR (Equivalent Series Resistance): 150R; Frequency Stability: 30ppm; Case/Package: CSMD; Component Kind: Standard; ComponentLink1Description: https://datasheet.ciiva.com/16410/abm10-16410918.pdf; ComponentLink1URL: https://datasheet.ciiva.com/16410/abm10-16410918.pdf; ComponentLink2Description: https://datasheet.ciiva.com/856/abm10-856088.pdf; ComponentLink2URL: https://datasheet.ciiva.com/856/abm10-856088.pdf; ComponentLink3Description: https://datasheet.ciiva.com/856/abm10-856089.pdf; ComponentLink3URL: https://datasheet.ciiva.com/856/abm10-856089.pdf; ComponentLink4Description: https://datasheet.ciiva.com/856/abm10-856090.pdf; ComponentLink4URL: https://datasheet.ciiva.com/856/abm10-856090.pdf; Depth: 2mm; Frequency: 16MHz; Frequency Tolerance: 20ppm; Height: 0.028inch; Lead Free: Lead Free; Length: 2.5mm; Library Name: Crystals.IntLib; Load Capacitance: 10pF; Max Operating Temperature: 85°C; Min Operating Temperature: -40°C; Mount: Surface Mount; Number of Pins: 4; Package Quantity: 3000; Packaging: Tape and Reel; Pricing 1: 5=1.18, 50=0.742, 250=0.675, 500=0.607, 1500=0.569, 3000=0.558, 15000=0.547 (EUR); REACH SVHC: No SVHC; Series Resistance: 150R; Stock 1: 8209; Supplier 1: Farnell; Supplier Part Number 1: 2467762; Termination: SMD/SMT; Tolerance: 20ppm; Width: 2mm
U2 = E22-900M22S: Component Kind: Standard; ComponentLink1Description: Datasheet; ComponentLink1URL: http://www.ebyte.com/en/downpdf.aspx?id=437; Library Name: Lora_modules.IntLib
